# Altium schematic sheet DW1000Breakout.SchDoc(DW1000Breakout)
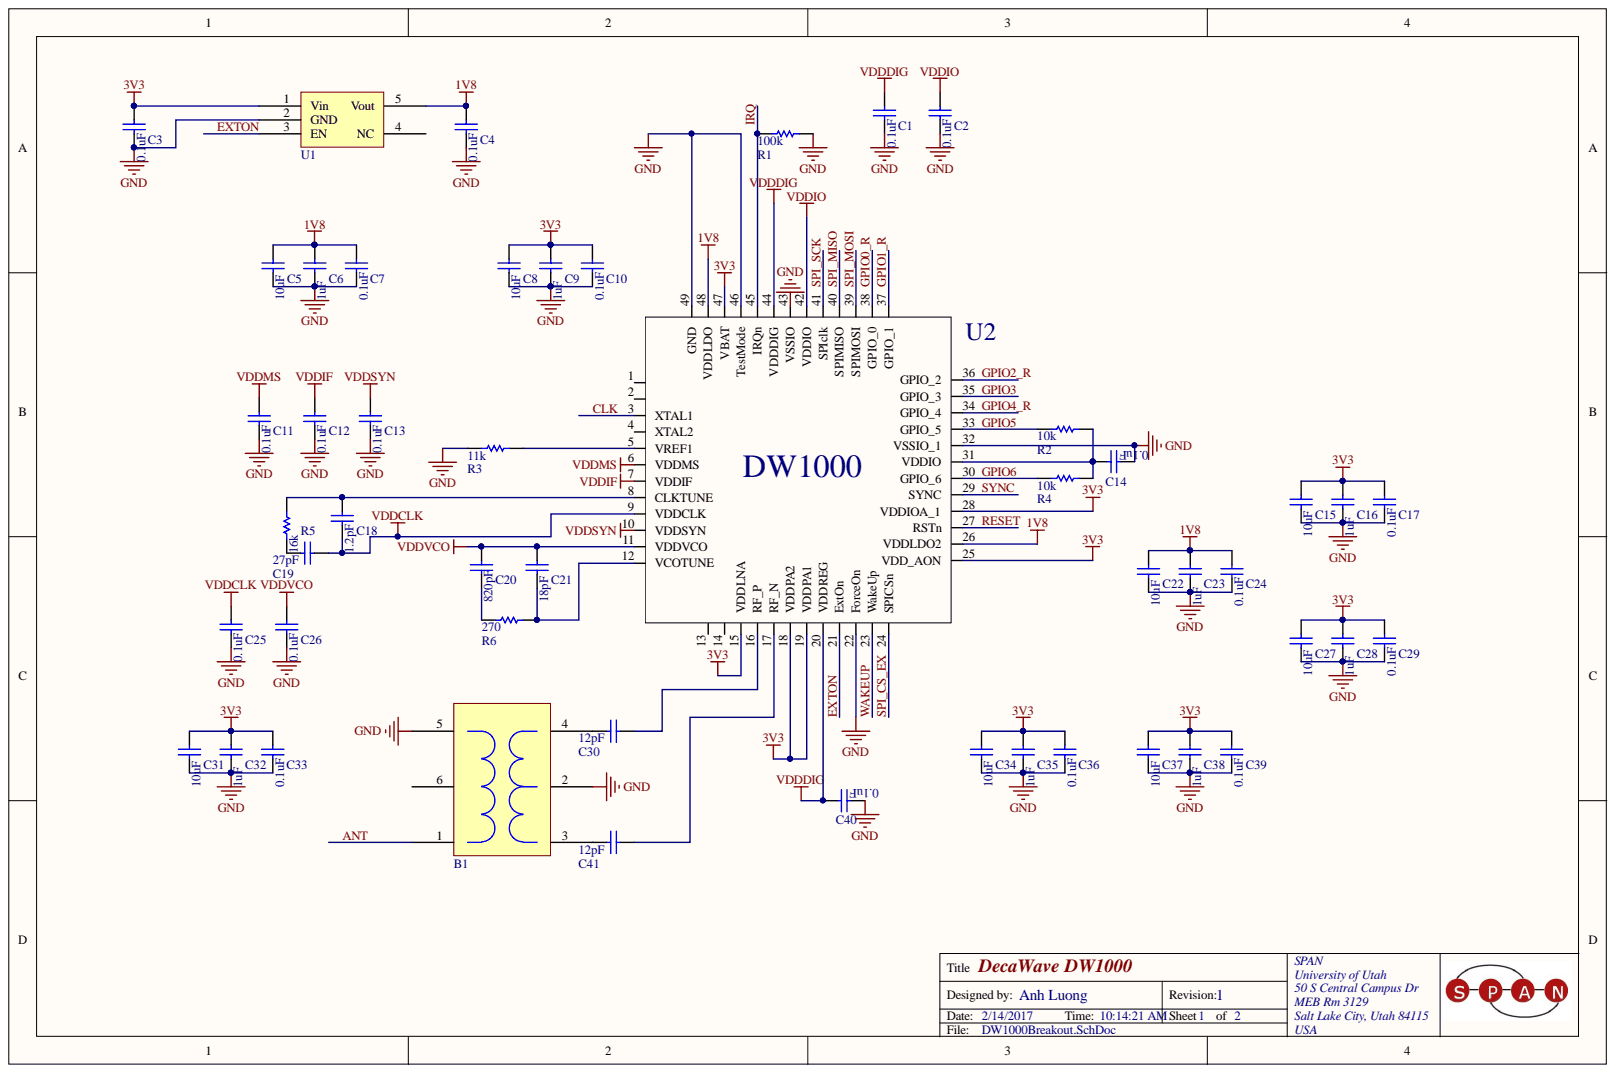
[diagram p0: DW1000Breakout.SchDoc]

## Parts
B1  HHM1595A1  [BALUN]  (value not drawn)
C1  GRM188R71C104KA01D  [CAPC1608X08N (0603)]  (value not drawn)
C2  GRM188R71C104KA01D  [CAPC1608X08N (0603)]  (value not drawn)
C3  GRM188R71C104KA01D  [CAPC1608X08N (0603)]  (value not drawn)
C4  GRM188R71C104KA01D  [CAPC1608X08N (0603)]  (value not drawn)
C5  GRM188R60J106ME47D  [CAPC1608X08N (0603)]  (value not drawn)
C6  GRM188R61A105KA61D  [CAPC1608X08N (0603)]  (value not drawn)
C7  GRM188R71C104KA01D  [CAPC1608X08N (0603)]  (value not drawn)
C8  GRM188R60J106ME47D  [CAPC1608X08N (0603)]  (value not drawn)
C9  GRM188R61A105KA61D  [CAPC1608X08N (0603)]  (value not drawn)
C10  GRM188R71C104KA01D  [CAPC1608X08N (0603)]  (value not drawn)
C11  GRM188R71C104KA01D  [CAPC1608X08N (0603)]  (value not drawn)
C12  GRM188R71C104KA01D  [CAPC1608X08N (0603)]  (value not drawn)
C13  GRM188R71C104KA01D  [CAPC1608X08N (0603)]  (value not drawn)
C14  GRM188R71C104KA01D  [CAPC1608X08N (0603)]  (value not drawn)
C15  GRM188R60J106ME47D  [CAPC1608X08N (0603)]  (value not drawn)
C16  GRM188R61A105KA61D  [CAPC1608X08N (0603)]  (value not drawn)
C17  GRM188R71C104KA01D  [CAPC1608X08N (0603)]  (value not drawn)
C18  Capacitance: 1.2pF  [CAPC1608X08N (0603)]  (hidden comment: GRM1885C1H1R2CA01D)
C19  Capacitance: 27pF  [CAPC1608X08N (0603)]  (hidden comment: GRM1885C1H270JA01D)
C20  Capacitance: 820pF  [CAPC1608X08N (0603)]  (hidden comment: GRM188R71H821KA01D)
C21  Capacitance: 18pF  [CAPC1608X08N (0603)]  (hidden comment: GRM1885C1H180JA01D)
C22  GRM188R60J106ME47D  [CAPC1608X08N (0603)]  (value not drawn)
C23  GRM188R61A105KA61D  [CAPC1608X08N (0603)]  (value not drawn)
C24  GRM188R71C104KA01D  [CAPC1608X08N (0603)]  (value not drawn)
C25  GRM188R71C104KA01D  [CAPC1608X08N (0603)]  (value not drawn)
C26  GRM188R71C104KA01D  [CAPC1608X08N (0603)]  (value not drawn)
C27  GRM188R60J106ME47D  [CAPC1608X08N (0603)]  (value not drawn)
C28  GRM188R61A105KA61D  [CAPC1608X08N (0603)]  (value not drawn)
C29  GRM188R71C104KA01D  [CAPC1608X08N (0603)]  (value not drawn)
C30  Capacitance: 12pF  [CAPC1608X08N (0603)]  (hidden comment: GRM1885C1H120JA01D)
C31  GRM188R60J106ME47D  [CAPC1608X08N (0603)]  (value not drawn)
C32  GRM188R61A105KA61D  [CAPC1608X08N (0603)]  (value not drawn)
C33  GRM188R71C104KA01D  [CAPC1608X08N (0603)]  (value not drawn)
C34  GRM188R60J106ME47D  [CAPC1608X08N (0603)]  (value not drawn)
C35  GRM188R61A105KA61D  [CAPC1608X08N (0603)]  (value not drawn)
C36  GRM188R71C104KA01D  [CAPC1608X08N (0603)]  (value not drawn)
C37  GRM188R60J106ME47D  [CAPC1608X08N (0603)]  (value not drawn)
C38  GRM188R61A105KA61D  [CAPC1608X08N (0603)]  (value not drawn)
C39  GRM188R71C104KA01D  [CAPC1608X08N (0603)]  (value not drawn)
C40  GRM188R71C104KA01D  [CAPC1608X08N (0603)]  (value not drawn)
C41  Capacitance: 12pF  [CAPC1608X08N (0603)]  (hidden comment: GRM1885C1H120JA01D)
R1  Resistance (Ohms): 100k  [RESC1608X06N (0603)]  (hidden comment: MCR03ERTJ104)
R2  Resistance (Ohms): 10k  [RESC1608X06N (0603)]  (hidden comment: MCR03ERTJ103)
R3  Resistance (Ohms): 11k  [RESC1608X06N (0603)]  (hidden comment: MCR03ERTJ113)
R4  Resistance (Ohms): 10k  [RESC1608X06N (0603)]  (hidden comment: MCR03ERTJ103)
R5  Resistance (Ohms): 16k  [RESC1608X06N (0603)]  (hidden comment: MCR03ERTJ163)
R6  MCR03ERTJ271  [RESC1608X06N (0603)]  (value not drawn)
U1  LP5907MFX-1.8/NOPB  [SOT95P280X145-5N]  (value not drawn)
U2  DW1000 IC  [QFN_48]  (value not drawn)

## Nets
1V8: C4.2 C5.2 C6.2 C7.2 C22.2 C23.2 C24.2 U1.5(Vout) U2.26(VDDLDO2) U2.48(VDDLDO)
3V3: C3.2 C8.2 C9.2 C10.2 C15.2 C16.2 C17.2 C27.2 C28.2 C29.2 C31.2 C32.2 C33.2 C34.2 C35.2 C36.2 C37.2 C38.2 C39.2 U1.1(Vin) U2.15(VDDLNA) U2.18(VDDPA2) U2.19(VDDPA1) U2.25(VDD_AON) U2.28(VDDIOA_1) U2.47(VBAT)
GND: B1.2 B1.5 C1.1 C2.1 C3.1 C4.1 C5.1 C6.1 C7.1 C8.1 C9.1 C10.1 C11.1 C12.1 C13.1 C14.1 C15.1 C16.1 C17.1 C22.1 C23.1 C24.1 C25.1 C26.1 C27.1 C28.1 C29.1 C31.1 C32.1 C33.1 C34.1 C35.1 C36.1 C37.1 C38.1 C39.1 C40.1 R1.2 R3.1 U1.2(GND) U2.22(ForceOn) U2.32(VSSIO_1) U2.43(VSSIO) U2.46(TestMode) U2.49(GND)
VDDCLK: C18.1 C19.2 C25.2 U2.9(VDDCLK)
VDDDIG: C1.2 C40.2 U2.20(VDDREG) U2.44(VDDDIG)
VDDIF: C12.2 U2.7(VDDIF)
VDDIO: C2.2 U2.42(VDDIO)
VDDMS: C11.2 U2.6(VDDMS)
VDDSYN: C13.2 U2.10(VDDSYN)
VDDVCO: C20.2 C21.2 C26.2 U2.11(VDDVCO)
ANT: B1.1
CLK: U2.3(XTAL1)
EXTON: U1.3(EN) U2.21(ExtOn)
GPIO0_R: U2.38(GPIO_0)
GPIO1_R: U2.37(GPIO_1)
GPIO2_R: U2.36(GPIO_2)
GPIO3: U2.35(GPIO_3)
GPIO4_R: U2.34(GPIO_4)
GPIO5: R2.1 U2.33(GPIO_5)
GPIO6: R4.1 U2.30(GPIO_6)
IRQ: R1.1 U2.45(IRQn)
RESET: U2.27(RSTn)
SPI_CS_EX: U2.24(SPICSn)
SPI_MISO: U2.40(SPIMISO)
SPI_MOSI: U2.39(SPIMOSI)
SPI_SCK: U2.41(SPIclk)
SYNC: U2.29(SYNC)
WAKEUP: U2.23(WakeUp)
NetB1_3: B1.3 C41.1
NetB1_4: B1.4 C30.1
NetC14_2: C14.2 R2.2 R4.2 U2.31(VDDIO)
NetC18_2: C18.2 R5.2 U2.8(CLKTUNE)
NetC19_1: C19.1 R5.1
NetC20_1: C20.1 R6.1
NetC21_1: C21.1 R6.2 U2.12(VCOTUNE)
NetC30_2: C30.2 U2.16(RF_P)
NetC41_2: C41.2 U2.17(RF_N)
NetR3_2: R3.2 U2.5(VREF1)

Not connected: B1 6; U1 4(NC); U2 1 2 4(XTAL2) 13 14

## Library data of the parts
B1 = HHM1595A1: XFMR BALUN RF 3.0-8.0GHZ UWB SMD; Manufacturer: TDK Corporation; Return Loss (Min): 8dB; Phase Difference: 180° ±20; Insertion Loss (Max): 1.5dB; Impedance - Unbalanced/Balanced: 50 / 100 Ohm; Frequency Range: 3GHz ~ 8GHz; Supplier Part Number 1: 445-3387-1-ND; Supplier 1: Digi-Key; Stock 1: 20610; RoHS: RoHS Compliant; Pricing 1: 1=1.38, 10=1.348, 100=0.7356, 250=0.66, 500=0.64626, 1000=0.4675 (USD); Part Status: Active; Packaging: Cut Tape (CT); Package / Case: 0805 (2012 Metric); Mounting Type: Surface Mount; Manufacturer Part Number: HHM1595A1; Library Name: DW1000Breakout.SchLib; ComponentLink1URL: https://product.tdk.com/info/en/documents/data_sheet/rf_balun_hhm1595a1_en.pdf; ComponentLink1Description: https://product.tdk.com/info/en/documents/data_sheet/rf_balun_hhm1595a1_en.pdf; Component Kind: Standard; Category: RF/IF and RFID
C1-C4 C7 C10-C14 C17 C24-C26 C29 C33 C36 C39 C40 = GRM188R71C104KA01D: CAP CER 0.1UF 16V 10% X7R 0603; Manufacturer: Murata Electronics North America; Capacitance: 0.1µF; Voltage - Rated: 16V; Tolerance: ±10%; Thickness (Max): 0.035" (0.90mm); Temperature Coefficient: X7R; Supplier 1: Digi-Key; Stock 1: 1458181; Size / Dimension: 0.063" L x 0.031" W (1.60mm x 0.80mm); RoHS: RoHS Compliant; Ratings: -; Pricing 1: 1=0.1, 10=0.019, 50=0.0102, 100=0.0086, 250=0.00704, 500=0.006, 1000=0.00468 (USD); Packaging: Cut Tape (CT); Package / Case: 0603 (1608 Metric); Operating Temperature: -55°C ~ 125°C; Mounting Type: Surface Mount, MLCC; Manufacturer Part Number: GRM188R71C104KA01D; Lead Style: -; Lead Spacing: -; Height - Seated (Max): -; Features: -; ComponentLink1URL: http://search.murata.co.jp/Ceramy/image/img/A01X/G101/ENG/GRM188R71C104KA01-01.pdf; ComponentLink1Description: http://search.murata.co.jp/Ceramy/image/img/A01X/G101/ENG/GRM188R71C104KA01-01.pdf; Component Kind: Standard; Category: Capacitors; Applications: General Purpose
  C1-C4 C11-C14 C40: Library Name: DW1000Breakout.SchLib
  C7 C10 C17 C24-C26 C29 C33 C36 C39: Library Name: DWM1000Breakout.SchLib
C5 C8 C15 C22 C27 C31 C34 C37 = GRM188R60J106ME47D: CAP CER 10UF 6.3V 20% X5R 0603; Manufacturer: Murata Electronics North America; Capacitance: 10µF; Voltage - Rated: 6.3V; Tolerance: ±20%; Thickness (Max): 0.035" (0.90mm); Temperature Coefficient: X5R; Supplier 2: Digi-Key; Supplier 1: Digi-Key; Stock 1: 2128324; Size / Dimension: 0.063" L x 0.031" W (1.60mm x 0.80mm); RoHS: RoHS Compliant; Ratings: -; Pricing 1: 1=0.24, 10=0.2, 50=0.1126, 100=0.1, 250=0.075, 500=0.06626, 1000=0.05875 (USD); Packaging: Digi-Reel®; Package / Case: 0603 (1608 Metric); Operating Temperature: -55°C ~ 85°C; Mounting Type: Surface Mount, MLCC; Manufacturer Part Number: GRM188R60J106ME47D; Lead Style: -; Lead Spacing: -; Height - Seated (Max): -; Features: -; ComponentLink1URL: http://search.murata.co.jp/Ceramy/image/img/A01X/G101/ENG/GRM188R60J106ME47-01.pdf; ComponentLink1Description: http://search.murata.co.jp/Ceramy/image/img/A01X/G101/ENG/GRM188R60J106ME47-01.pdf; Component Kind: Standard; Category: Capacitors; Applications: General Purpose; Library Name: DWM1000Breakout.SchLib
C6 C9 C16 C23 C28 C32 C35 C38 = GRM188R61A105KA61D: CAP CER 1UF 10V 10% X5R 0603; Manufacturer: Murata Electronics North America; Capacitance: 1.0µF; Voltage - Rated: 10V; Tolerance: ±10%; Thickness (Max): 0.035" (0.90mm); Temperature Coefficient: X5R; Supplier 1: Digi-Key; Stock 1: 244919; Size / Dimension: 0.063" L x 0.031" W (1.60mm x 0.80mm); RoHS: RoHS Compliant; Ratings: -; Pricing 1: 1=0.1, 10=0.041, 50=0.0224, 100=0.019, 250=0.01552, 500=0.01328, 1000=0.01035 (USD); Packaging: Cut Tape (CT); Package / Case: 0603 (1608 Metric); Operating Temperature: -55°C ~ 85°C; Mounting Type: Surface Mount, MLCC; Manufacturer Part Number: GRM188R61A105KA61D; Lead Style: -; Lead Spacing: -; Height - Seated (Max): -; Features: -; ComponentLink1URL: http://search.murata.co.jp/Ceramy/image/img/A01X/G101/ENG/GRM188R61A105KA61-01.pdf; ComponentLink1Description: http://search.murata.co.jp/Ceramy/image/img/A01X/G101/ENG/GRM188R61A105KA61-01.pdf; Component Kind: Standard; Category: Capacitors; Applications: General Purpose; Library Name: DWM1000Breakout.SchLib
C18 = GRM1885C1H1R2CA01D: CAP CER 1.2PF 50V NP0 0603; Manufacturer: Murata Electronics North America; Tolerance: ±0.25pF; Temperature Coefficient: C0G, NP0; Voltage - Rated: 50V; Thickness (Max): 0.035" (0.90mm); Supplier Part Number 1: 490-10709-1-ND; Supplier 1: Digi-Key; Stock 1: 36680; Size / Dimension: 0.063" L x 0.031" W (1.60mm x 0.80mm); RoHS: RoHS Compliant; Ratings: -; Pricing 1: 1=0.1, 10=0.053, 50=0.0286, 100=0.0242, 250=0.0198, 500=0.01694, 1000=0.0132 (USD); Part Status: Active; Packaging: Cut Tape (CT); Package / Case: 0603 (1608 Metric); Operating Temperature: -55°C ~ 125°C; Mounting Type: Surface Mount, MLCC; Manufacturer Part Number: GRM1885C1H1R2CA01D; Library Name: DW1000Breakout.SchLib; Lead Style: -; Lead Spacing: -; Height - Seated (Max): -; Features: -; ComponentLink1URL: http://www.murata.com/~/media/webrenewal/support/library/catalog/products/capacitor/mlcc/c02e.pdf; ComponentLink1Description: http://www.murata.com/~/media/webrenewal/support/library/catalog/products/capacitor/mlcc/c02e.pdf; Component Kind: Standard; Category: Capacitors; Applications: General Purpose
C19 = GRM1885C1H270JA01D: CAP CER 27PF 50V NP0 0603; Manufacturer: Murata Electronics North America; Tolerance: ±5%; Temperature Coefficient: C0G, NP0; Voltage - Rated: 50V; Thickness (Max): 0.035" (0.90mm); Supplier Part Number 1: 490-1413-1-ND; Supplier 1: Digi-Key; Stock 1: 113117; Size / Dimension: 0.063" L x 0.031" W (1.60mm x 0.80mm); RoHS: RoHS Compliant; Ratings: -; Pricing 1: 1=0.1, 10=0.041, 50=0.0222, 100=0.0187, 250=0.01532, 500=0.0131, 1000=0.0102 (USD); Part Status: Active; Packaging: Cut Tape (CT); Package / Case: 0603 (1608 Metric); Operating Temperature: -55°C ~ 125°C; Mounting Type: Surface Mount, MLCC; Manufacturer Part Number: GRM1885C1H270JA01D; Library Name: DW1000Breakout.SchLib; Lead Style: -; Lead Spacing: -; Height - Seated (Max): -; Features: -; ComponentLink1URL: http://www.murata.com/~/media/webrenewal/support/library/catalog/products/capacitor/mlcc/c02e.pdf; ComponentLink1Description: http://www.murata.com/~/media/webrenewal/support/library/catalog/products/capacitor/mlcc/c02e.pdf; Component Kind: Standard; Category: Capacitors; Applications: General Purpose
C20 = GRM188R71H821KA01D: CAP CER 820PF 50V X7R 0603; Manufacturer: Murata Electronics North America; Tolerance: ±10%; Voltage - Rated: 50V; Thickness (Max): 0.035" (0.90mm); Temperature Coefficient: X7R; Supplier Part Number 1: 490-1493-1-ND; Supplier 1: Digi-Key; Stock 1: 61936; Size / Dimension: 0.063" L x 0.031" W (1.60mm x 0.80mm); RoHS: RoHS Compliant; Ratings: -; Pricing 1: 1=0.1, 10=0.067, 25=0.0476, 50=0.0364, 100=0.0308, 250=0.0252, 500=0.02156, 1000=0.0168 (USD); Part Status: Active; Packaging: Cut Tape (CT); Package / Case: 0603 (1608 Metric); Operating Temperature: -55°C ~ 125°C; Mounting Type: Surface Mount, MLCC; Manufacturer Part Number: GRM188R71H821KA01D; Library Name: DW1000Breakout.SchLib; Lead Style: -; Lead Spacing: -; Height - Seated (Max): -; Features: -; ComponentLink1URL: http://www.murata.com/~/media/webrenewal/support/library/catalog/products/capacitor/mlcc/c02e.pdf; ComponentLink1Description: http://www.murata.com/~/media/webrenewal/support/library/catalog/products/capacitor/mlcc/c02e.pdf; Component Kind: Standard; Category: Capacitors; Applications: General Purpose
C21 = GRM1885C1H180JA01D: CAP CER 18PF 50V NP0 0603; Manufacturer: Murata Electronics North America; Tolerance: ±5%; Temperature Coefficient: C0G, NP0; Voltage - Rated: 50V; Thickness (Max): 0.035" (0.90mm); Supplier Part Number 1: 490-1409-1-ND; Supplier 1: Digi-Key; Stock 1: 281577; Size / Dimension: 0.063" L x 0.031" W (1.60mm x 0.80mm); RoHS: RoHS Compliant; Ratings: -; Pricing 1: 1=0.1, 10=0.041, 50=0.0222, 100=0.0187, 250=0.01532, 500=0.0131, 1000=0.0102 (USD); Part Status: Active; Packaging: Cut Tape (CT); Package / Case: 0603 (1608 Metric); Operating Temperature: -55°C ~ 125°C; Mounting Type: Surface Mount, MLCC; Manufacturer Part Number: GRM1885C1H180JA01D; Library Name: DW1000Breakout.SchLib; Lead Style: -; Lead Spacing: -; Height - Seated (Max): -; Features: -; ComponentLink1URL: http://www.murata.com/~/media/webrenewal/support/library/catalog/products/capacitor/mlcc/c02e.pdf; ComponentLink1Description: http://www.murata.com/~/media/webrenewal/support/library/catalog/products/capacitor/mlcc/c02e.pdf; Component Kind: Standard; Category: Capacitors; Applications: General Purpose
C30 C41 = GRM1885C1H120JA01D: CAP CER 12PF 50V NP0 0603; Manufacturer: Murata Electronics North America; Tolerance: ±5%; Temperature Coefficient: C0G, NP0; Voltage - Rated: 50V; Thickness (Max): 0.035" (0.90mm); Supplier Part Number 1: 490-1405-1-ND; Supplier 1: Digi-Key; Stock 1: 151484; Size / Dimension: 0.063" L x 0.031" W (1.60mm x 0.80mm); RoHS: RoHS Compliant; Ratings: -; Pricing 1: 1=0.1, 10=0.041, 50=0.0222, 100=0.0187, 250=0.01532, 500=0.0131, 1000=0.0102 (USD); Part Status: Active; Packaging: Cut Tape (CT); Package / Case: 0603 (1608 Metric); Operating Temperature: -55°C ~ 125°C; Mounting Type: Surface Mount, MLCC; Manufacturer Part Number: GRM1885C1H120JA01D; Library Name: DW1000Breakout.SchLib; Lead Style: -; Lead Spacing: -; Height - Seated (Max): -; Features: -; ComponentLink1URL: http://www.murata.com/~/media/webrenewal/support/library/catalog/products/capacitor/mlcc/c02e.pdf; ComponentLink1Description: http://www.murata.com/~/media/webrenewal/support/library/catalog/products/capacitor/mlcc/c02e.pdf; Component Kind: Standard; Category: Capacitors; Applications: General Purpose
R1 = MCR03ERTJ104: RES SMD 100K OHM 5% 1/10W 0603; Manufacturer: Rohm Semiconductor; Temperature Coefficient: ±200ppm/°C; Power (Watts): 0.1W, 1/10W; Tolerance: ±5%; Supplier Part Number 1: RHM100KCGCT-ND; Supplier Device Package: 0603; Supplier 1: Digi-Key; Stock 1: 136580; Size / Dimension: 0.063" L x 0.031" W (1.60mm x 0.80mm); RoHS: RoHS Compliant; Pricing 1: 1=0.1, 10=0.019, 25=0.0132, 100=0.0075, 250=0.00576, 500=0.0046, 1000=0.00338 (USD); Part Status: Active; Packaging: Cut Tape (CT); Package / Case: 0603 (1608 Metric); Operating Temperature: -55°C ~ 155°C; Number of Terminations: 2; Manufacturer Part Number: MCR03ERTJ104; Library Name: DW1000Breakout.SchLib; Height: 0.022" (0.55mm); Features: -; Failure Rate: -; Composition: Thick Film; ComponentLink1URL: http://rohmfs.rohm.com/en/products/databook/datasheet/passive/resistor/chip_resistor/mcr_g.pdf; ComponentLink1Description: http://rohmfs.rohm.com/en/products/databook/datasheet/passive/resistor/chip_resistor/mcr_g.pdf; Component Kind: Standard; Category: Resistors
R2 R4 = MCR03ERTJ103: RES 10K OHM 1/10W 5% 0603 SMD; Manufacturer: Rohm Semiconductor; Temperature Coefficient: ±200ppm/°C; Power (Watts): 0.1W, 1/10W; Tolerance: ±5%; Supplier Device Package: 0603 (1608 Metric); Supplier 1: Digi-Key; Stock 1: 330000; Size / Dimension: 0.063" L x 0.031" W (1.60mm x 0.80mm); RoHS: RoHS Compliant; Pricing 1: 5000=0.00103, 10000=0.00089, 25000=0.00078, 50000=0.00072, 125000=0.0007 (USD); Packaging: Tape & Reel (TR); Package / Case: 0603 (1608 Metric); Number of Terminations: 2; Manufacturer Part Number: MCR03ERTJ103; Library Name: DW1000Breakout.SchLib; Height: 0.022" (0.55mm); Features: -; Composition: Thick Film; ComponentLink1URL: http://rohmfs.rohm.com/en/products/databook/datasheet/passive/resistor/chip_resistor/mcr_g.pdf; ComponentLink1Description: http://rohmfs.rohm.com/en/products/databook/datasheet/passive/resistor/chip_resistor/mcr_g.pdf; Component Kind: Standard; Category: Resistors
R3 = MCR03ERTJ113: RES SMD 11K OHM 5% 1/10W 0603; Manufacturer: Rohm Semiconductor; Temperature Coefficient: ±200ppm/°C; Power (Watts): 0.1W, 1/10W; Tolerance: ±5%; Supplier Part Number 1: RHM11KCGCT-ND; Supplier Device Package: 0603; Supplier 1: Digi-Key; Stock 1: 8319; Size / Dimension: 0.063" L x 0.031" W (1.60mm x 0.80mm); RoHS: RoHS Compliant; Pricing 1: 1=0.1, 10=0.019, 25=0.0132, 100=0.0075, 250=0.00576, 500=0.0046, 1000=0.00338 (USD); Part Status: Active; Packaging: Cut Tape (CT); Package / Case: 0603 (1608 Metric); Operating Temperature: -55°C ~ 155°C; Number of Terminations: 2; Manufacturer Part Number: MCR03ERTJ113; Library Name: DW1000Breakout.SchLib; Height: 0.022" (0.55mm); Features: -; Failure Rate: -; Composition: Thick Film; ComponentLink1URL: http://rohmfs.rohm.com/en/products/databook/datasheet/passive/resistor/chip_resistor/mcr_g.pdf; ComponentLink1Description: http://rohmfs.rohm.com/en/products/databook/datasheet/passive/resistor/chip_resistor/mcr_g.pdf; Component Kind: Standard; Category: Resistors
R5 = MCR03ERTJ163: RES SMD 16K OHM 5% 1/10W 0603; Manufacturer: Rohm Semiconductor; Temperature Coefficient: ±200ppm/°C; Power (Watts): 0.1W, 1/10W; Tolerance: ±5%; Supplier Part Number 1: RHM16KCGCT-ND; Supplier Device Package: 0603; Supplier 1: Digi-Key; Stock 1: 7457; Size / Dimension: 0.063" L x 0.031" W (1.60mm x 0.80mm); RoHS: RoHS Compliant; Pricing 1: 1=0.1, 10=0.019, 25=0.0132, 100=0.0075, 250=0.00576, 500=0.0046, 1000=0.00338 (USD); Part Status: Active; Packaging: Cut Tape (CT); Package / Case: 0603 (1608 Metric); Operating Temperature: -55°C ~ 155°C; Number of Terminations: 2; Manufacturer Part Number: MCR03ERTJ163; Library Name: DW1000Breakout.SchLib; Height: 0.022" (0.55mm); Features: -; Failure Rate: -; Composition: Thick Film; ComponentLink1URL: http://rohmfs.rohm.com/en/products/databook/datasheet/passive/resistor/chip_resistor/mcr_g.pdf; ComponentLink1Description: http://rohmfs.rohm.com/en/products/databook/datasheet/passive/resistor/chip_resistor/mcr_g.pdf; Component Kind: Standard; Category: Resistors
R6 = MCR03ERTJ271: RES SMD 270 OHM 5% 1/10W 0603; Manufacturer: Rohm Semiconductor; Temperature Coefficient: ±200ppm/°C; Resistance (Ohms): 270; Power (Watts): 0.1W, 1/10W; Tolerance: ±5%; Supplier Part Number 1: RHM270CGCT-ND; Supplier Device Package: 0603; Supplier 1: Digi-Key; Stock 1: 34918; Size / Dimension: 0.063" L x 0.031" W (1.60mm x 0.80mm); RoHS: RoHS Compliant; Pricing 1: 1=0.1, 10=0.019, 25=0.0132, 100=0.0075, 250=0.00576, 500=0.0046, 1000=0.00338 (USD); Part Status: Active; Packaging: Cut Tape (CT); Package / Case: 0603 (1608 Metric); Operating Temperature: -55°C ~ 155°C; Number of Terminations: 2; Manufacturer Part Number: MCR03ERTJ271; Library Name: DW1000Breakout.SchLib; Height: 0.022" (0.55mm); Features: -; Failure Rate: -; Composition: Thick Film; ComponentLink1URL: http://rohmfs.rohm.com/en/products/databook/datasheet/passive/resistor/chip_resistor/mcr_g.pdf; ComponentLink1Description: http://rohmfs.rohm.com/en/products/databook/datasheet/passive/resistor/chip_resistor/mcr_g.pdf; Component Kind: Standard; Category: Resistors
U1 = LP5907MFX-1.8/NOPB: IC REG LDO 1.8V 0.25A SOT23-5; Manufacturer: Texas Instruments; Voltage - Input: 2.2 V ~ 5.5 V; Regulator Topology: Positive Fixed; Number of Regulators: 1; Current - Output: 250mA; Voltage - Output: 1.8V; Voltage - Dropout (Typical): -; Supplier Part Number 1: 296-41463-1-ND; Supplier Device Package: SOT-23; Supplier 1: Digi-Key; Stock 1: 13246; RoHS: RoHS Compliant; Pricing 1: 1=0.57, 10=0.488, 100=0.3641, 500=0.28602, 1000=0.1575 (USD); Part Status: Active; Packaging: Cut Tape (CT); Package / Case: SC-74A, SOT-753; Operating Temperature: -40°C ~ 125°C; Mounting Type: Surface Mount; Manufacturer Part Number: LP5907MFX-1.8/NOPB; Library Name: DW1000Breakout.SchLib; Current - Limit (Min): -; ComponentLink1URL: http://www.ti.com/lit/ds/symlink/lp5907.pdf; ComponentLink1Description: http://www.ti.com/lit/ds/symlink/lp5907.pdf; Component Kind: Standard; Category: Integrated Circuits (ICs)
U2 = DW1000_QFN_48: UWB DW1000; Manufacturer: Decawave Ltd.; Part Number: DW1000_QFN; Library Name: DW1000.SCHLIB; Component Type: Standard; ComponentLink1Description: Datasheet Link; Component Kind: Standard; Component Code: n/a
